# Altium schematic sheet BandpassV2.SchDoc(BandpassV2)
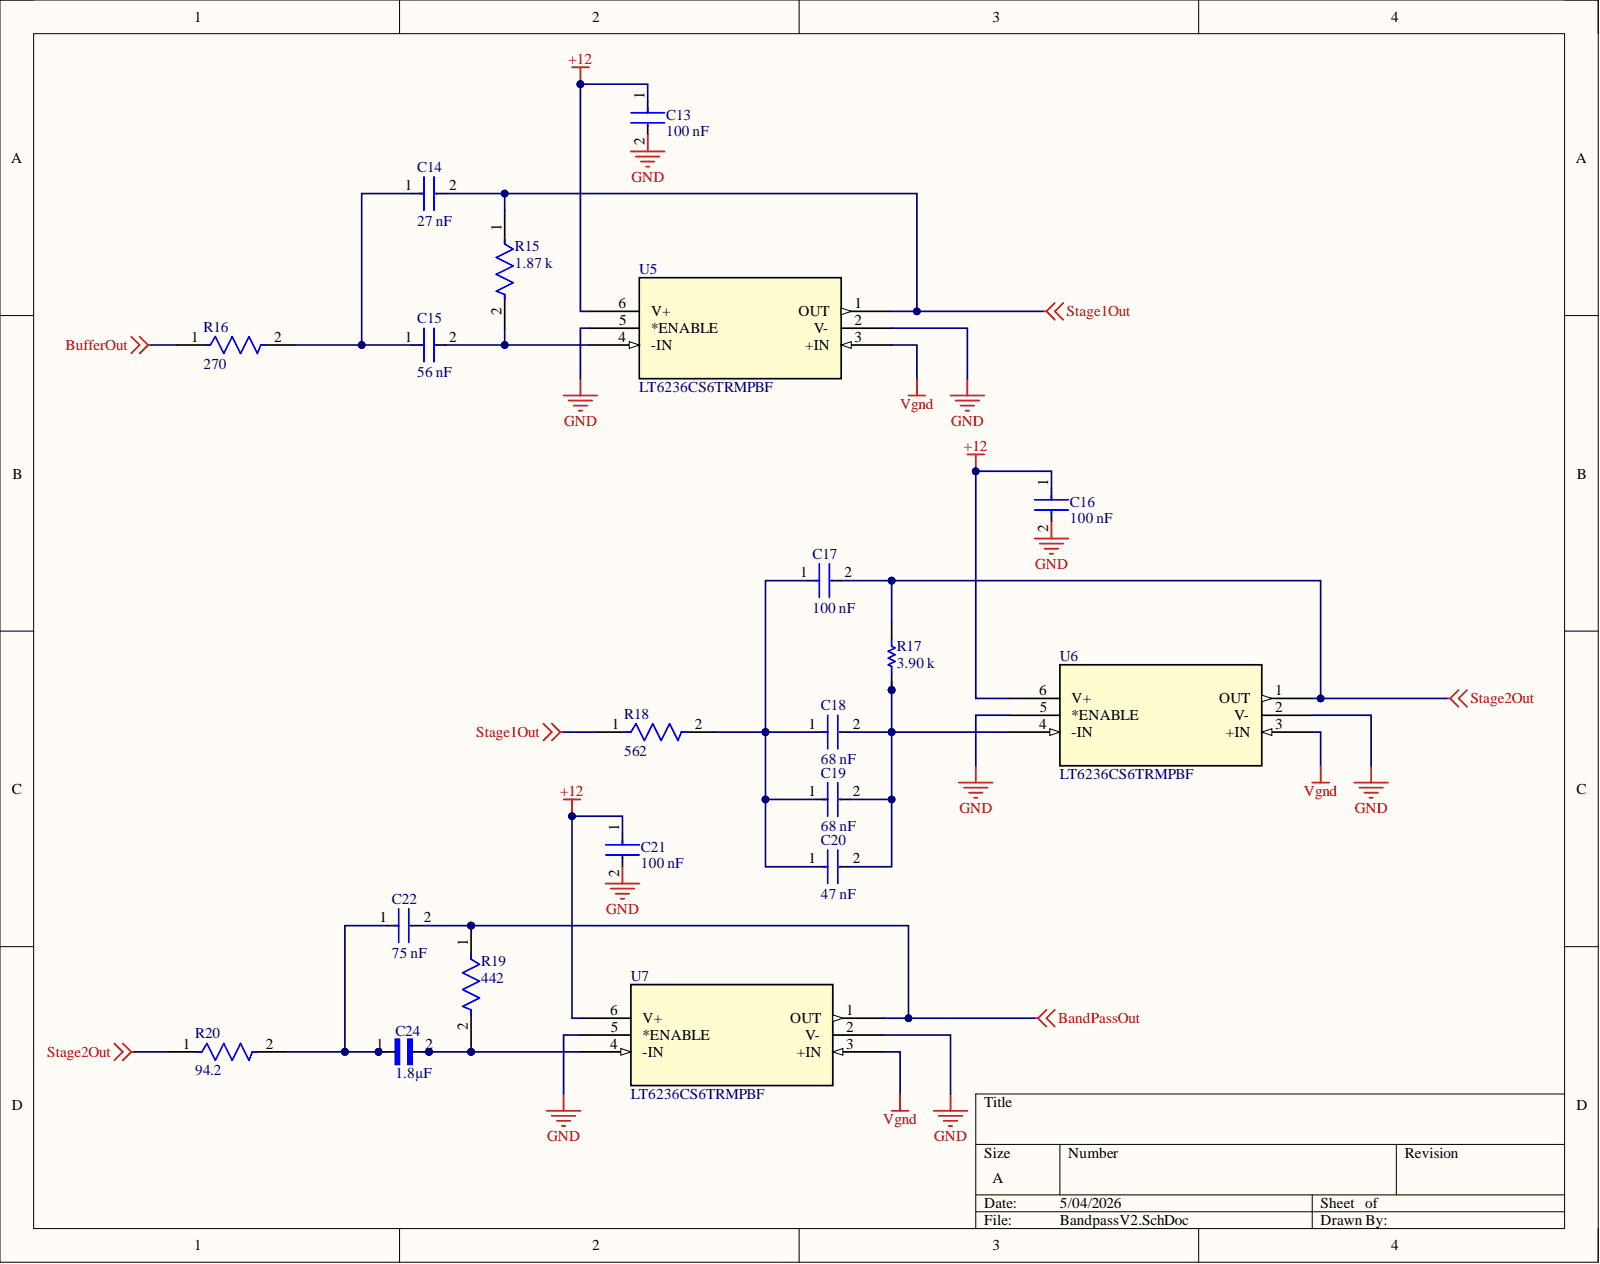
[diagram p0: BandpassV2.SchDoc]

## Parts
C13  100 nF
C14  27 nF
C15  56 nF
C16  100 nF
C17  100 nF
C18  68 nF
C19  68 nF
C20  47 nF
C21  100 nF
C22  75 nF
C24  value 1.8µF  (hidden comment: ECWFD2W185K)
R15  1.87 k
R16  270
R17  3.90 k
R18  562
R19  442
R20  94.2
U5  LT6236CS6TRMPBF
U6  LT6236CS6TRMPBF
U7  LT6236CS6TRMPBF

## Nets
+12: C13.1 C16.1 C21.1 U5.6(V+) U6.6(V+) U7.6(V+)
BandPassOut: C22.2 R19.1 U7.1(OUT)
BufferOut: R16.1
GND: C13.2 C16.2 C21.2 U5.2(V-) U5.5(*ENABLE) U6.2(V-) U6.5(*ENABLE) U7.2(V-) U7.5(*ENABLE)
Stage1Out: C14.2 R15.1 R18.1 U5.1(OUT)
Stage2Out: C17.2 R17.1 R20.1 U6.1(OUT)
Vgnd: U5.3(+IN) U6.3(+IN) U7.3(+IN)
NetC14_1: C14.1 C15.1 R16.2
NetC15_2: C15.2 R15.2 U5.4(-IN)
NetC17_1: C17.1 C18.1 C19.1 C20.1 R18.2
NetC18_2: C18.2 C19.2 C20.2 R17.2 U6.4(-IN)
NetC22_1: C22.1 C24.1 R20.2
NetC24_2: C24.2 R19.2 U7.4(-IN)

## Library data of the parts
C13 C16 C17 C21 = CMP-06035-028588-1: Chip Multilayer Ceramic Capacitors for General Purpose, 1206, 0.10uF, C0G, 30ppm/°C, 5%, 50V; Component Kind: Standard; Library Name: Altium Content Vault
C14 = CMP-06035-003643-1: Chip Multilayer Ceramic Capacitors for General Purpose, 1206, 27000pF, C0G, 30ppm/°C, 5%, 50V; Capacitance: 27nF; Case Code (Imperial): 1206; Case Code (Metric): 3216; Case/Package: 1206; Component Kind: Standard; Depth: 1.6mm; Dielectric: C0G; Height: 0.85mm; Lead Free: Lead Free; Length: 3.2mm; Library Name: Altium Content Vault; Max Operating Temperature: 125°C; Min Operating Temperature: -55°C; Mount: Surface Mount; Package Quantity: 4000; Packaging: Tape and Reel; Radiation Hardening: No; Termination: SMD/SMT; Thickness: 0.037inch; Tolerance: 5%; Voltage: 50V; Voltage Rating: 50V; Voltage Rating (DC): 50V; Width: 0.063inch
C15 = CMP-1037-04037-2: Chip Multilayer Ceramic Capacitors for General Purpose, 1206, 56000pF, C0G, 30ppm/°C, 5%, 50V; Capacitance: 56nF; Case Code (Imperial): 1206; Case Code (Metric): 3216; Case/Package: 1206; Component Kind: Standard; Depth: 1.6mm; Dielectric: C0G; Height: 1.15mm; Lead Free: Lead Free; Length: 3.2mm; Library Name: Altium Content Vault; Max Operating Temperature: 125°C; Min Operating Temperature: -55°C; Mount: Surface Mount; Package Quantity: 3000; Packaging: Tape and Reel; Radiation Hardening: No; Termination: SMD/SMT; Thickness: 0.049inch; Tolerance: 5%; Voltage Rating: 50V; Voltage Rating (DC): 50V; Width: 0.063inch
C18 C19 = CMP-06035-047801-1: Chip Multilayer Ceramic Capacitors for General Purpose, 1206, 68000pF, C0G, 30ppm/°C, 5%, 50V; Component Kind: Standard; Library Name: Altium Content Vault
C20 = CMP-06035-048734-1: Chip Multilayer Ceramic Capacitors for General Purpose, 1206, 47000pF, C0G, 30ppm/°C, 5%, 50V; Component Kind: Standard; Library Name: Altium Content Vault
C22 = CMP-06035-031430-1: Chip Multilayer Ceramic Capacitors for General Purpose, 1206, 75000pF, C0G, 30ppm/°C, 5%, 50V; Capacitance: 75nF; Case Code (Imperial): 1206; Case Code (Metric): 3216; Case/Package: 1206; Component Kind: Standard; Depth: 1.6mm; Dielectric: C0G; Height: 1.6mm; Length: 3.2mm; Library Name: Altium Content Vault; Max Operating Temperature: 125°C; Min Operating Temperature: -55°C; Mount: Surface Mount; Package Quantity: 2000; Packaging: Tape and Reel; Radiation Hardening: No; Termination: SMD/SMT; Thickness: 0.071inch; Tolerance: 5%; Voltage Rating: 50V; Voltage Rating (DC): 50V; Weight: 0.000571oz; Width: 0.063inch
C24 = CMP-001-00084-1: Availability: Unavailable; Case/Package: None; Component Kind: Standard; Component Type: Standard; Design Item ID: ECWFD2W185K; MP: ECWFD2W185K; Pins: 2; Price: None; Source: ECWFD2W185K.SchLib; Library Name: James Brasfield
R15 = CMP-03412-006609-1: RES SMD 1.87K OHM 0.1% 1/8W 0805; Manufacturer: Yageo; Manufacturer Part Number: RT0805BRD071K87L; Power Rating: 0.125W; Resistance: 1.87KΩ; Temperature Coefficient: 25 ppm/°C; Tolerance: 0.0025; Value: 1.87KΩ; Voltage Rating: 150V; Automotive: No; Category: Res; Component Kind: Standard; ComponentLink2Description: Datasheet; ComponentLink2URL: http://www.yageo.com/documents/recent/PYu-RT_1-to-0.01_RoHS_L_9.pdf; Composition: Thin Film; Device Class L1: Passive Components; Device Class L2: Resistors; Device Class L3: Chip SMD Resistors; Digikey Description: RES SMD 1.87K OHM 0.1% 1/8W 0805; Footprint Url: http://www.yageo.com/exep/pages/download/literatures/PYu-R_Mount_10.pdf; Height: 0.6mm; Ipc Land Pattern Name: RESC200125X50; Lead Free: Yes; Library Name: Altium Content Vault; Mouser Description: Thin Film Resistors - SMD 1/8W 1.87K ohm .1% 25ppm; Octopart: https://octopart.com/rt0805brd071k87l-yageo-1397037; Package: RT0805; RoHS: TRUE; Series: RT; Temperature: -55°C to +155°C; Temperature Range High: +155°C; Temperature Range Low: -55°C
R16 = CMP-2001-03354-2: 270Ω ±0.5% 0.1W 1/10W Chip Resistor 0805 (2012 Metric) Thin Film; Max Power Dissipation: 100mW; Resistance: 270R; Temperature Coefficient: 25ppm/°C; Voltage Rating: 100V; Case Code (Imperial): 0805; Case Code (Metric): 2012; Case/Package: 0805; Component Kind: Standard; Depth: 1.25mm; Height: 0.4mm; Lead Free: Lead Free; Length: 2mm; Library Name: Altium Content Vault; Max Operating Temperature: 125°C; Min Operating Temperature: -55°C; Number of Pins: 2; Number of Terminations: 2; Package Quantity: 1; Packaging: Tape and Reel; Power Rating: 100mW; Resistance Tolerance: 0.5%; Resistor Type: Thin Film; RoHS Compliant: Yes; Series: RR; Size Code: 0805; Technology: THIN FILM; Termination: SMD/SMT; Tolerance: 0.5%; Voltage Rating (DC): 100V; Width: 0.049inch
R17 = CMP-2001-00635-1: Manufacturer: Panasonic; Part Number: ERA6AEB392V; Component Kind: Standard; Library Name: Altium Content Vault
R18 = CMP-26527-004545-1: 562Ω ±1% 0.25W 0805 High Power Anti-Sulfur Thin Film Chip Resistor; Max Power Dissipation: 250mW; Resistance: 562R; Temperature Coefficient: 100ppm/°C; Case Code (Imperial): 0805; Case Code (Metric): 2012; Case/Package: 0805; Component Kind: Standard; Features: Moisture Resistant; Height: 0.024inch; Length: 0.079inch; Library Name: Altium Content Vault; Max Operating Temperature: 155°C; Min Operating Temperature: -55°C; Mount: Surface Mount; Number of Terminations: 2; Packaging: Tape and Reel; Resistor Type: Thin Film; RoHS Compliant: Yes; Series: RNCP; Tolerance: 1%; Width: 0.049inch
R19 = CMP-04015-026884-1: 442Ω ±0.1% 0.125W, 1/8W Chip Resistor 0805 (2012 Metric) Moisture Resistant Thin Film; Component Kind: Standard; Library Name: Altium Content Vault
R20 = CMP-04015-053274-1: 94.2Ω ±0.1% 0.1W, 1/10W Chip Resistor 0603 (1608 Metric) Moisture Resistant Thin Film; Component Kind: Standard; Library Name: Altium Content Vault
U5-U7 = CMP-014-00002-1: Rail-to-Rail Output 215MHz, 1.1nV/âˆšHz Op Amp/ SAR ADC Driver; Manufacturer_Name: Analog Devices Inc; Manufacturer_Part_Number: LT6236CS6#TRMPBF; Component Kind: Standard; Datasheet: https://www.analog.com/media/en/technical-documentation/data-sheets/623637fb.pdf; Design Item ID: LT6236CS6TRMPBF; RefDes: RefDes; Source: LT6236CS6TRMPBF.SchLib; Type: Type; Copyright: Copyright (C) 2026 Ultra Librarian. All rights reserved.; Library Name: James Brasfield
